# Altium schematic sheet I_PRV_UWS_B2_1_0b_PSU.SchDoc
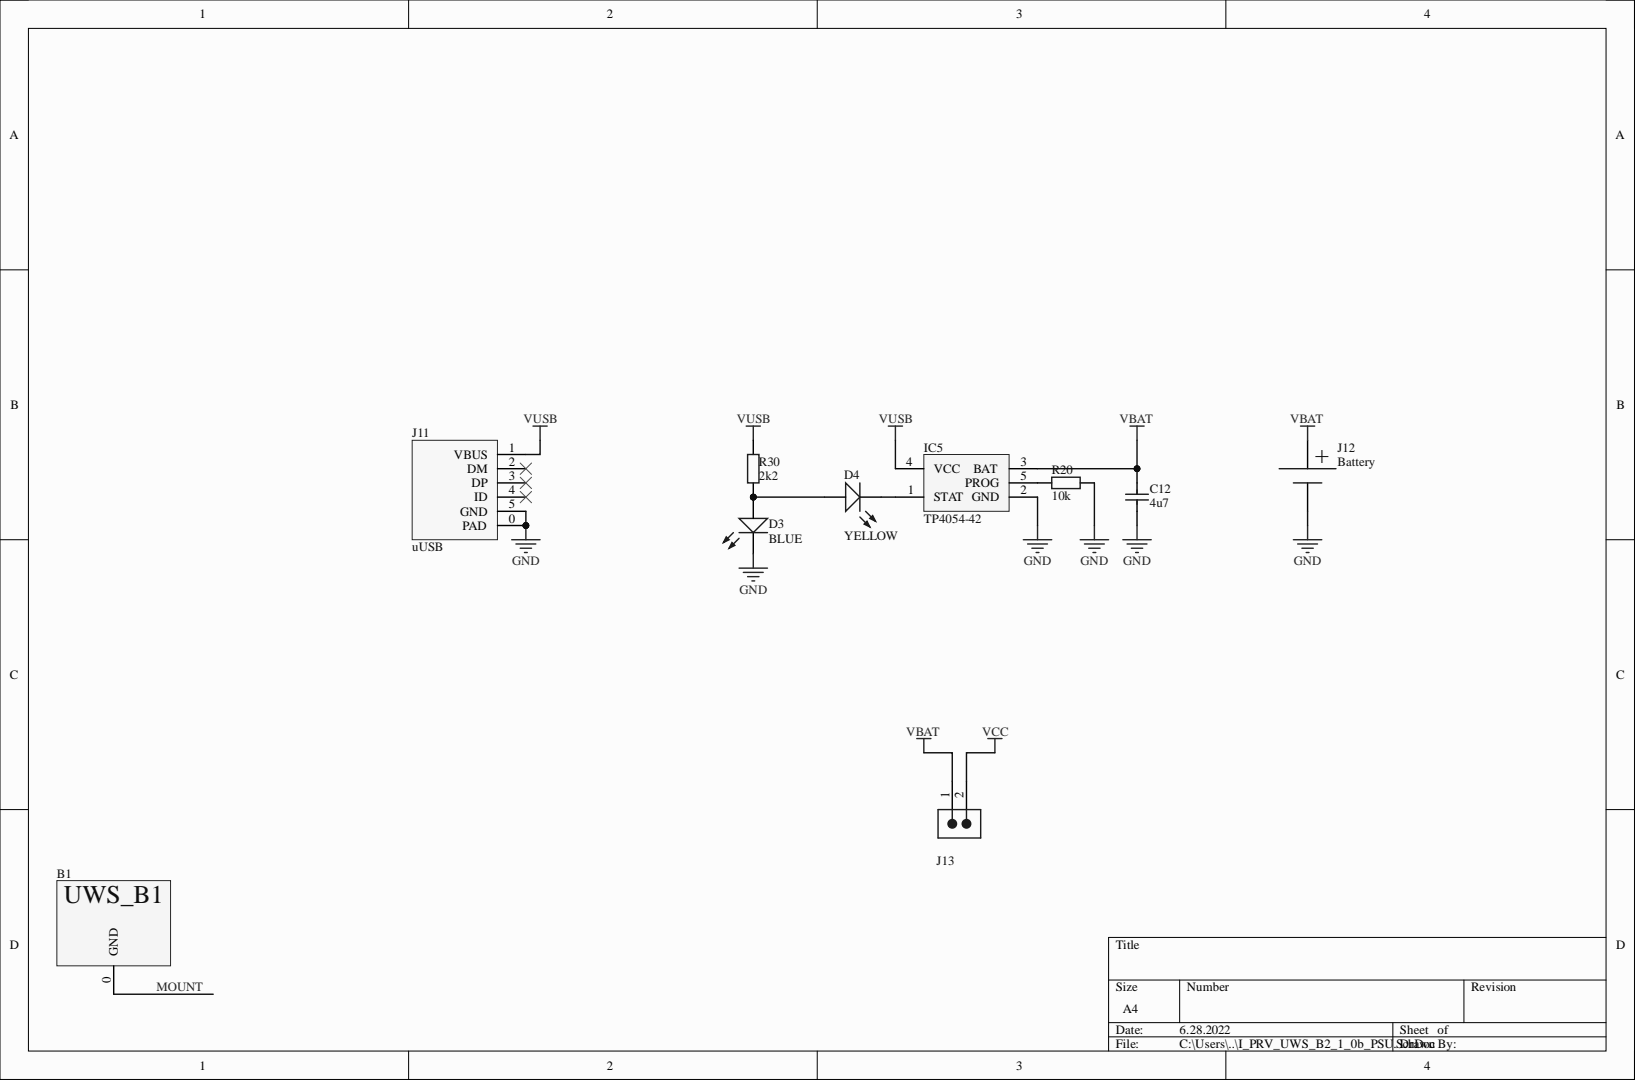
[diagram p0: I_PRV_UWS_B2_1_0b_PSU.SchDoc]

## Parts
B1  UWS_B1_outline  [UWS_B1_outline]  (value not drawn)
C12  value 4u7  [SMD0603_CAP]  (hidden comment: Capacitor)
D3  value BLUE  [SMD0603_LED]  (hidden comment: LED)
D4  value YELLOW  [SMD0603_LED]  (hidden comment: LED)
IC5  TP4054-42  [SOT23-5]
J11  uUSB  [microUSB]
J12  Battery  [LiIon_UWS]
J13  Pinhead 2pin 2.54mm  [Pinhead 2pi 2.54mm]  (value not drawn)
R20  value 10k  [SMD0402_RES]  (hidden comment: Resistor)
R30  value 2k2  [SMD0402_RES]  (hidden comment: Resistor)

## Nets
GND: C12.1 D3.1 IC5.2(GND) J11.0(PAD) J11.5(GND) J12.1 R20.2
VBAT: C12.2 IC5.3(BAT) J12.2 J13.1
VCC: J13.2
VUSB: IC5.4(VCC) J11.1(VBUS) R30.2
MOUNT: B1.0
NetD3_2: D3.2 D4.2 R30.1
NetD4_1: D4.1 IC5.1(STAT)
NetIC5_5: IC5.5(PROG) R20.1

Not connected: J11 2(DM) 3(DP) 4(ID)

## Library data of the parts
IC5 = MCP73832T: MCP73832T bat charger; Component Kind: Standard; LCSC Part #: C32574; Library Name: BM_UWS.SchLib
J11 = MicroUSB: Component Kind: Standard; Library Name: BM_UWS.SchLib
J12 = BAT: Component Kind: Standard; Library Name: BM_UWS.SchLib
B1 = UWS_B1_outline: Component Kind: Standard; Library Name: BM_UWS.SchLib
C12 = Capacitor: Component Kind: Standard; Description: Capacitor; LCSC Part #: C19666; Library Name: KD_SCH_LIB.SchLib
D3 D4 = LED: Component Kind: Standard; Description: LED; Library Name: KD_SCH_LIB.SchLib
  D3: LCSC Part #: C72041
  D4: LCSC Part #: C72038
J13 = Pinhead 2pin 2.54mm: Component Kind: Standard; Library Name: BM_UWS.SchLib
R20 R30 = Resistor: Component Kind: Standard; Description: Resistor; Library Name: KD_SCH_LIB.SchLib
  R20: LCSC Part #: C25744
  R30: LCSC Part #: C25879
